# Altium schematic sheet RFID_PCB_Antenna_Main_circuit.SchDoc
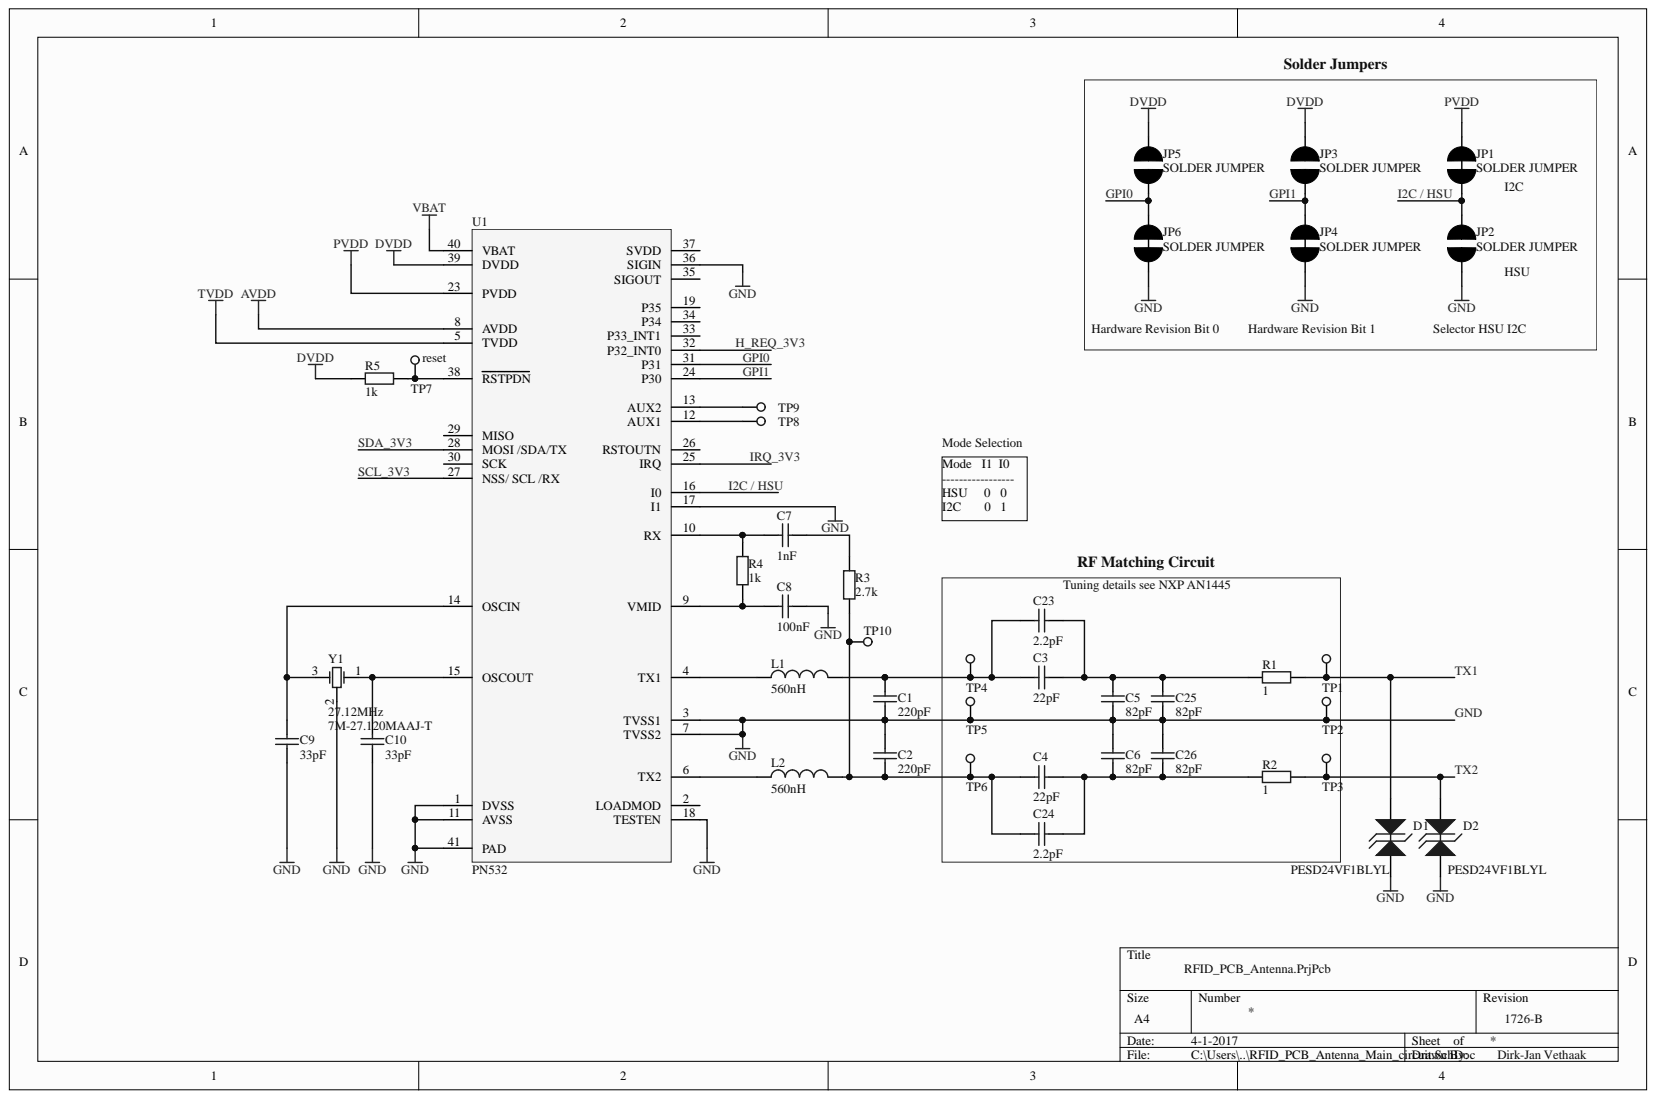
[diagram p0: RFID_PCB_Antenna_Main_circuit.SchDoc]

## Parts
C1  220pF  [cap0402]
C2  220pF  [cap0402]
C3  22pF  [cap0402]
C4  22pF  [cap0402]
C5  82pF  [cap0402]
C6  82pF  [cap0402]
C7  1nF  [cap0402]
C8  100nF  [cap0402]
C9  33pF  [cap0402]
C10  33pF  [cap0402]
C23  2.2pF  [cap0402]
C24  2.2pF  [cap0402]
C25  82pF  [cap0402]
C26  82pF  [cap0402]
D1  PESD24VF1BL  Manufacturer Part Number: PESD24VF1BLYL  [DFN65P100X50-2N]
D2  PESD24VF1BL  Manufacturer Part Number: PESD24VF1BLYL  [DFN65P100X50-2N]
JP1  SOLDER JUMPER  [Jumper Solder 0402]
JP2  SOLDER JUMPER  [Jumper Solder 0402]
JP3  SOLDER JUMPER  [Jumper Solder 0402]
JP4  SOLDER JUMPER  [Jumper Solder 0402]
JP5  SOLDER JUMPER  [Jumper Solder 0402]
JP6  SOLDER JUMPER  [Jumper Solder 0402]
L1  560nH  [C0603]
L2  560nH  [C0603]
R1  1  [RESC1105X04N]
R2  1  [RESC1105X04N]
R3  2.7k  [RESC1105X04N]
R4  1k  [RESC1105X04N]
R5  1k  [RESC1105X04N]
TP1  Testpoint  [Testpoint]  (value not drawn)
TP2  Testpoint  [Testpoint]  (value not drawn)
TP3  Testpoint  [Testpoint]  (value not drawn)
TP4  Testpoint  [Testpoint]  (value not drawn)
TP5  Testpoint  [Testpoint]  (value not drawn)
TP6  Testpoint  [Testpoint]  (value not drawn)
TP7  Testpoint  [TESTPOINT]  (value not drawn)
TP8  Testpoint  [Testpoint]  (value not drawn)
TP9  Testpoint  [Testpoint]  (value not drawn)
TP10  Testpoint  [Testpoint]  (value not drawn)
U1  PN532  [QFN50P600X600X100_HS-41N]
Y1  27.12MHz  [DFN170P250X71-4N]

## Nets
AVDD: U1.8(AVDD)
DVDD: JP3.2 JP5.2 R5.2 U1.39(DVDD)
PVDD: JP1.2 U1.23(PVDD)
TVDD: U1.5(TVDD)
VBAT: U1.40(VBAT)
GND: C1.1 C2.2 C5.1 C6.2 C8.1 C9.1 C10.1 C25.1 C26.2 D1.1 D2.1 JP2.1 JP4.1 JP6.1 TP2.1 TP5.1 U1.1(DVSS) U1.3(TVSS1) U1.7(TVSS2) U1.11(AVSS) U1.17(I1) U1.18(TESTEN) U1.36(SIGIN) U1.41(PAD) Y1.2
GPI0: JP5.1 JP6.2 U1.31(P31)
GPI1: JP3.1 JP4.2 U1.24(P30)
H_REQ_3V3: U1.32(P32_INT0)
I2C / HSU: JP1.1 JP2.2 U1.16(I0)
IRQ_3V3: U1.25(IRQ)
SCL_3V3: U1.27(NSS/_SCL_/RX)
SDA_3V3: U1.28(MOSI_/SDA/TX)
TX1: D1.2 R1.2 TP1.1
TX2: D2.2 R2.2 TP3.1
NetC1_2: C1.2 C3.1 C23.1 L1.2 TP4.1
NetC2_1: C2.1 C4.1 C24.1 L2.2 R3.1 TP6.1 TP10.1
NetC3_2: C3.2 C5.2 C23.2 C25.2 R1.1
NetC4_2: C4.2 C6.1 C24.2 C26.1 R2.1
NetC7_1: C7.1 R4.2 U1.10(RX)
NetC7_2: C7.2 R3.2
NetC8_2: C8.2 R4.1 U1.9(VMID)
NetC9_2: C9.2 U1.14(OSCIN) Y1.3
NetC10_2: C10.2 U1.15(OSCOUT) Y1.1
NetL1_1: L1.1 U1.4(TX1)
NetL2_1: L2.1 U1.6(TX2)
NetR5_1: R5.1 TP7.1 U1.38(RSTPDN)
NetTP8_1: TP8.1 U1.12(AUX1)
NetTP9_1: TP9.1 U1.13(AUX2)

Not connected: U1 2(LOADMOD) 19(P35) 26(RSTOUTN) 29(MISO) 30(SCK) 33(P33_INT1) 34(P34) 35(SIGOUT) 37(SVDD)

## Library data of the parts
C1 C2 = C0402: Manufacturer: Murata Electronics North America; Manufacturer Part Number: GRM1555C1H221JA01D; Temperature Coefficient: C0G, NP0; Tolerance: ±5%; Voltage - Rated: 50V; Applications: General Purpose; Capacitance: 220pF; Category: Capacitors; Component Kind: Standard; ComponentLink1Description: http://www.murata.com/~/media/webrenewal/support/library/catalog/products/capacitor/mlcc/c02e.pdf; ComponentLink1URL: http://www.murata.com/~/media/webrenewal/support/library/catalog/products/capacitor/mlcc/c02e.pdf; Description: C0402; Features: -; Height - Seated (Max): -; Lead Spacing: -; Lead Style: -; Mounting Type: Surface Mount, MLCC; Operating Temperature: -55°C ~ 125°C; Package / Case: 0402 (1005 Metric); Packaging: Tape & Reel (TR); Pricing 1: 10000=0.00442, 20000=0.00421, 30000=0.004, 50000=0.00358, 100000=0.00289 (EUR); Ratings: -; RoHS: RoHS Compliant; Size / Dimension: 0.039" L x 0.020" W (1.00mm x 0.50mm); Stock 1: 650000; Supplier 1: Digi-Key; Supplier Part Number 1: 490-1293-2-ND; Thickness (Max): 0.022" (0.55mm); Library Name: RFIDboard.SchLib
C3 C4 = C0402: Manufacturer: Murata Electronics North America; Manufacturer Part Number: GRM1555C1H220FA01D; Temperature Coefficient: C0G, NP0; Tolerance: ±1%; Voltage - Rated: 50V; Applications: General Purpose; Capacitance: 22pF; Category: Capacitors; Component Kind: Standard; ComponentLink1Description: http://www.murata.com/~/media/webrenewal/support/library/catalog/products/capacitor/mlcc/c02e.pdf; ComponentLink1URL: http://www.murata.com/~/media/webrenewal/support/library/catalog/products/capacitor/mlcc/c02e.pdf; Description: C0402; Features: -; Height - Seated (Max): -; Lead Spacing: -; Lead Style: -; Mounting Type: Surface Mount, MLCC; Operating Temperature: -55°C ~ 125°C; Package / Case: 0402 (1005 Metric); Packaging: Tape & Reel (TR); Pricing 1: 10000=0.00677, 20000=0.00645, 30000=0.00613, 50000=0.00548, 100000=0.00443 (EUR); Ratings: -; RoHS: RoHS Compliant; Size / Dimension: 0.039" L x 0.020" W (1.00mm x 0.50mm); Stock 1: 150000; Supplier 1: Digi-Key; Supplier Part Number 1: 490-8589-2-ND; Thickness (Max): 0.022" (0.55mm); Library Name: RFIDboard.SchLib
C5 C6 C25 C26 = C0402: Manufacturer: AVX; Manufacturer Part Number: 04025A820FAT2A; Capacitance: 82; Capacitance Tolerance: ± 1%; Capacitor Terminals: SMD; Ceramic Capacitor Case: 0402 [1005 Metric]; Dielectric Characteristic: C0G / NP0; External Depth: 0.5; External Length / Height: 0.25; External Width: 1; No. of Pins: 2; SVHC: No SVHC (17-Dec-2015); Temperature Coefficient: 0ppm/°C ± 30ppm/°C<!--FANDB--><div class="features-and-benefits-container"><div class="features-and-benefits-description"><span class="features-benefits-value">The AVX multilayer ceramic capacitors with EIA class I, C0G (NP0) dielectric material are highly stable ceramic chip capacitors for general purpose applications. This multilayer ceramic capacitor is a monolithic block of ceramic containing two sets of offset, interleaved planar electrodes that extend to two opposite surfaces of ceramic dielectric.</span></div><div class="features-and-benefits-list"><ul class="features-and-benefits-list-list"><li class="features-and-benefits-list-item">Capacitance value ranges from 0.5pF to 0.1?F</li><li class="features-and-benefits-list-item">EIA 0101, 0201, 0402, 0603, 0805, 1206, 1210, 1812, 1825, 2220, 2225 case sizes available</li><li class="features-and-benefits-list-item">Rated DC voltage of 6.3V, 10V, 16V, 25V, 50V, 100V, 200V, 500V</li><li class="features-and-benefits-list-item">±0.10pF, ±0.25pF, ±0.50pF, ±1%, ±2%, ±5% and ±10% tolerance</li><li class="features-and-benefits-list-item">Nickel (Ni) and tin (Sn) plated terminations</li><li class="features-and-benefits-list-item">Load life of 1000h at 125?C</li><li class="features-and-benefits-list-item">Operating temperature range -55?C to 125; Voltage Rating: 50; Capacitance Tolerance ±: ± 1%; Component Kind: Standard; ComponentLink1Description: http://www.farnell.com/datasheets/425357.pdf; ComponentLink1URL: http://www.farnell.com/datasheets/425357.pdf; Description: C0402; MSL: MSL 1 - Unlimited; Operating Temperature Max: 125; Operating Temperature Min: -55; Operating Temperature Range: -55°C to +125; Packaging: Cut Tape; Pricing 1: 10=0.379, 100=0.076, 500=0.038, 2500=0.0228, 5000=0.019, 10000=0.0163, 50000=0.0143, 100000=0.0127 (GBP); Product Range: -; RoHS: YES; Stock 1: 22436; Supplier 1: Farnell; Supplier Part Number 1: 1740575; Library Name: RFIDboard.SchLib
C7 = C0402: Manufacturer Part Number: VJ0402Y102KXAAC; Brand: Vishay / Vitramon; Capacitance: 1000 pF; Dielectric: X7R; Qualification: Commercial; Tolerance: 10 %; Type: Commercial SMD MLCCs; Voltage Rating DC: 50 VDC; Case Code - in: 0402; Case Code - mm: 1005; Category: Multilayer Ceramic Capacitors MLCC - SMD/SMT; Component Kind: Standard; ComponentLink1Description: http://www.mouser.com/ds/2/427/vjcommercialseries-222796.pdf; ComponentLink1URL: http://www.mouser.com/ds/2/427/vjcommercialseries-222796.pdf; Description: C0402; Height: 0.6 mm; Length: 1 mm; Manufacturer: Vishay / Vitramon; Maximum Operating Temperature: + 125 C; Minimum Operating Temperature: - 55 C; Minimum Order Quantity: 1; Package / Case: 0402 (1005 metric); Packaging: Reel; Pricing 1: 1=0.060, 50=0.050, 100=0.044, 500=0.043, 5000=0.043 (USD); Product: General Type MLCCs; Product Category: Ceramic Chip Capacitors; Reeling: True; RoHS: RoHS Compliant; RoHS - Mouser: Y; Series: VJ COMM; Stock 1: 19151; Supplier 1: Mouser; Supplier Part Number 1: 77-VJ0402Y102KXAAC; Termination Style: SMD/SMT; Voltage Rating AC: -; Width: 0.5 mm; Library Name: RFIDboard.SchLib
C8 = C0402: Manufacturer Part Number: VJ0402G104KXQCW1BC; Brand: Vishay / Vitramon; Capacitance: 0.1 uF; Dielectric: X5R; Qualification: Commercial; Tolerance: 10 %; Type: Ultra Small Commodity MLCCs; Voltage Rating DC: 10 VDC; Case Code - in: 0402; Case Code - mm: 1005; Category: Multilayer Ceramic Capacitors MLCC - SMD/SMT; Component Kind: Standard; ComponentLink1Description: http://www.mouser.com/ds/2/427/vjw1bcbascomseries-223529.pdf; ComponentLink1URL: http://www.mouser.com/ds/2/427/vjw1bcbascomseries-223529.pdf; Description: C0402; Height: 0.55 mm; Length: 1 mm; Manufacturer: Vishay / Vitramon; Maximum Operating Temperature: + 85 C; Minimum Operating Temperature: - 55 C; Minimum Order Quantity: 1; Package / Case: 0402 (1005 metric); Packaging: Reel; Pricing 1: 1=0.100, 50=0.080, 100=0.066, 500=0.047, 1000=0.028, 10000=0.021, 20000=0.019, 50000=0.012, 100000=0.010 (USD); Product: General Type MLCCs; Product Category: Ceramic Chip Capacitors; Reeling: True; RoHS: RoHS Compliant; RoHS - Mouser: Y; Series: VJ W1BC; Stock 1: 26687; Supplier 1: Mouser; Supplier Part Number 1: 77-VJ0402G104KXQCBC; Termination Style: SMD/SMT; Voltage Rating AC: -; Width: 0.5 mm; Library Name: RFIDboard.SchLib
C9 C10 = C0402: Manufacturer: MURATA; Manufacturer Part Number: GRM1555C1H330JA01D; Capacitance: 33; Capacitance Tolerance: ± 5%; Ceramic Capacitor Case: 0402 [1005 Metric]; Dielectric Characteristic: C0G / NP0; Product Range: GRM Series; SVHC: No SVHC (17-Dec-2015; Voltage Rating: 50; Component Kind: Standard; ComponentLink1Description: http://www.farnell.com/datasheets/2047983.pdf; ComponentLink1URL: http://www.farnell.com/datasheets/2047983.pdf; Description: C0402; MSL: MSL 1 - Unlimited; Operating Temperature Max: 125; Operating Temperature Min: -55; Packaging: Cut Tape; Pricing 1: 10=0.0078, 100=0.0064, 500=0.005, 2500=0.0033, 5000=0.0032, 10000=0.0026, 50000=0.002, 100000=0.0019 (GBP); RoHS: YES; Stock 1: 32150; Supplier 1: Farnell; Supplier Part Number 1: 2434643; Library Name: RFIDboard.SchLib
C23 C24 = C0402: Manufacturer: MURATA; Manufacturer Part Number: GRM1555C1H2R2CA01D; Capacitance: 2.2; Capacitance Tolerance: ± 0.25; Ceramic Capacitor Case: 0402 [1005 Metric]; Dielectric Characteristic: C0G / NP0; Product Range: GRM Series; SVHC: No SVHC (17-Dec-2015)<!--FANDB--><div class="features-and-benefits-container"><div class="features-and-benefits-description"><span class="features-benefits-value">The GRM1555C1H2R2CA01D is a Multilayer Ceramic Capacitor for general purpose, C0G/NP0 dielectric and 0402 case. Since the external electrodes consist of a plated structure, the product is excellent in soldering heat resistance. The profile dimensions have been standardized with high precision, therefore high reliability can be acquired in the case of automatic mounting.</span></div><div class="features-and-benefits-list"><ul class="features-and-benefits-list-list"><li class="features-and-benefits-list-item">High reliability with no polarity</li><li class="features-and-benefits-list-item">Low impedance in high frequencies and excellent in pulse response and noise elimination</li></ul></div><div class="features-and-benefits-applications"><ul class="features-and-benefits-value"><li class="features-and-benefits-list-item">Industrial</li></ul></div></div>; Voltage Rating: 50; Component Kind: Standard; ComponentLink1Description: http://www.farnell.com/datasheets/2047874.pdf; ComponentLink1URL: http://www.farnell.com/datasheets/2047874.pdf; Description: C0402; MSL: MSL 1 - Unlimited; Operating Temperature Max: 125; Operating Temperature Min: -55; Packaging: Cut Tape; Pricing 1: 10=0.0091, 100=0.0057, 500=0.005, 2500=0.0033, 5000=0.0032, 10000=0.0024, 50000=0.002, 100000=0.0018 (GBP); RoHS: YES; Stock 1: 133410; Supplier 1: Farnell; Supplier Part Number 1: 2434609; Library Name: RFIDboard.SchLib
D1 D2 = Biploar TVS Diode: TVS DIODE 24VWM 17VC DFN1006-2; Manufacturer: NXP Semiconductors; Bidirectional Channels: 1; Capacitance @ Frequency: 0.3pF @ 1MHz; Current - Peak Pulse (10/1000µs): 1A (8/20µs); Power Line Protection: No; Type: Zener; Voltage - Breakdown (Min): 24.5V; Voltage - Clamping (Max) @ Ipp: 17V; Voltage - Reverse Standoff (Typ): 24V (Max); Applications: Automotive; Category: Circuit Protection; Component Kind: Standard; ComponentLink1Description: http://www.nxp.com/documents/data_sheet/PESD24VF1BL.pdf; ComponentLink1URL: http://www.nxp.com/documents/data_sheet/PESD24VF1BL.pdf; Mounting Type: Surface Mount; Operating Temperature: -55°C ~ 150°C (TA); Package / Case: SOD-882; Packaging: Tape & Reel (TR); Power - Peak Pulse: -; Pricing 1: 10000=0.09736, 30000=0.0893, 50000=0.08595, 100000=0.08393, 250000=0.08259 (EUR); RoHS: RoHS Compliant; Stock 1: 30000; Supplier 1: Digi-Key; Supplier Device Package: SOD-882; Supplier Part Number 1: 568-12543-2-ND; Unidirectional Channels: -; Library Name: RFIDboard.SchLib
JP1-JP6 = (no symbol name): Manufacturer: VISHAY; Manufacturer Part Number: CRCW04020000Z0ED; ID: Library_CON-0010; Power Rating: 63; Product Range: AEC-Q200 CRCW Series; Resistance: 0; Resistor Case Style: 0402 [1005 Metric]; Resistor Element Material: Thick Film; Resistor Mounting: Surface Mount Device<!--FANDB--><div class="features-and-benefits-container"><div class="features-and-benefits-description"><span class="features-benefits-value">The Vishay D/CRCW e3 series are standard thick film chip resistors. The resistance range includes E96 and E24 decade values from 1ohm to 10Mohm. CRCW e3 series resistors have a pure tin solder contacts on the Ni barrier layer that provides compatibility with reflow soldering process.</span></div><div class="features-and-benefits-list"><ul class="features-and-benefits-list-list"><li class="features-and-benefits-list-item">Automotive grade AEC-Q200 qualified</li><li class="features-and-benefits-list-item">EIA 0402, 0603, 0805, 1206, 1210, 1218, 2010, 2512 standard case sizes available</li><li class="features-and-benefits-list-item">Stability &Delta; Temperature Coefficient: ± 100; Voltage Rating: 50; Component Kind: Standard; ComponentLink1Description: http://www.farnell.com/datasheets/2045673.pdf; ComponentLink1URL: http://www.farnell.com/datasheets/2045673.pdf; ComponentLink2Description: http://www.farnell.com/cad/1551206.zip; ComponentLink2URL: http://www.farnell.com/cad/1551206.zip; Description: SOLDER JUMPER; Mfg: -; Mfg code: -; MSL: MSL 1 - Unlimited; Packaging: Cut Tape; Pricing 1: 10=0.0194, 300=0.0152, 1000=0.0099, 2500=0.0078, 5000=0.0052 (GBP); Resistance Tolerance: -; RoHS: Y-EX; Stock 1: 111126; Supplier 1: Farnell; Value: SOLDER JUMPER; Library Name: RFIDboard.SchLib
L1 L2 = (no symbol name): Murata LQW18CNR56J00D 560nH 5%; Manufacturer: Murata Electronics North America; Current Rating: 450mA; DC Resistance (DCR): 480 mOhm Max; Frequency - Self Resonant: 540MHz; Frequency - Test: 10MHz; Material - Core: Ferrite; Shielding: Unshielded; Type: Wirewound; Category: Inductors, Coils, Chokes; Component Kind: Standard; ComponentLink1Description: http://www.murata.com/~/media/webrenewal/support/library/catalog/products/inductor/chip/o05e.ashx; ComponentLink1URL: http://www.murata.com/~/media/webrenewal/support/library/catalog/products/inductor/chip/o05e.ashx; Current - Saturation: -; Height - Seated (Max): 0.037" (0.95mm); Inductance: 560nH; Manufacturer Part Number: LQW18CNR56J00D; Mounting Type: Surface Mount; Operating Temperature: -40°C ~ 85°C; Package / Case: 0603 (1608 Metric); Packaging: Cut Tape (CT); Pricing 1: 1=0.47, 10=0.415, 25=0.39, 50=0.3376, 100=0.3117, 250=0.29612, 500=0.27014, 750=0.23897, 1000=0.22858 (EUR); Q @ Freq: -; Ratings: -; RoHS: RoHS Compliant; Size / Dimension: 0.063" L x 0.031" W (1.60mm x 0.80mm); Stock 1: 10277; Supplier 1: Digi-Key; Supplier Part Number 1: 490-10774-1-ND; Tolerance: ±5%; Library Name: RFIDboard.SchLib
R1 R2 = R0402: Manufacturer: MULTICOMP; Manufacturer Part Number: MCMR04W1R00FTL; Power Rating: 62.5; Product Range: MCMR Series; Resistance Tolerance: ± 1%; Resistor Case Style: 0402 [1005 Metric]; Resistor Element Material: Ceramic; Resistor Mounting: Surface Mount Device<!--FANDB--><div class="features-and-benefits-container"><div class="features-and-benefits-description"><span class="features-benefits-value">The Multicomp MCMR series resistors are automotive grade, anti sulphured thick film chip resistor. The resistance range includes E24 and E96 decade values from 1ohm to 10Mohm. These resistors are constructed on top of a high grade ceramic body.</span></div><div class="features-and-benefits-list"><ul class="features-and-benefits-list-list"><li class="features-and-benefits-list-item">EIA 0402, 0603, 0805, 1206 chip sizes available</li><li class="features-and-benefits-list-item">High reliability and stability</li><li class="features-and-benefits-list-item">Automotive grade AEC-Q200 compliant</li><li class="features-and-benefits-list-item">Complaint with (IEC 60068-1) 55/155/56 climatic category</li><li class="features-and-benefits-list-item">Maximum operation voltage of 50V, 75V, 150V and 200V</li><li class="features-and-benefits-list-item">Power rating of 62.5mW, 100mW, 125mW and 250mW</li><li class="features-and-benefits-list-item">Available in ±1% and ±5% tolerance</li><li class="features-and-benefits-list-item">Temperature coefficient (TCR) of +200ppm/°C, +100ppm/°C and -200ppm/°C to +400ppm/°C</li><li class="features-and-benefits-list-item">Operating temperature range -55°C to 155; SVHC: No SVHC (17-Dec-2015); Temperature Coefficient: -200ppm/°C to +400; Voltage Rating: 50; Component Kind: Standard; ComponentLink1Description: http://www.farnell.com/datasheets/1716705.pdf; ComponentLink1URL: http://www.farnell.com/datasheets/1716705.pdf; Description: R0402; MSL: -; Packaging: Cut Tape; Pricing 1: 10=0.0192, 100=0.0151, 500=0.0109, 2500=0.0047, 5000=0.004, 10000=0.0033, 50000=0.0023, 100000=0.002 (GBP); Resistance: 1; RoHS: YES; Stock 1: 17702; Supplier 1: Farnell; Supplier Part Number 1: 2072500; Library Name: RFIDboard.SchLib
R3 = R0402: Manufacturer: Vishay Dale; Manufacturer Part Number: CRCW04022K70FKED; Power (Watts): 0.063W, 1/16W; Temperature Coefficient: ±100ppm/°C; Tolerance: ±1%; Category: Resistors; Component Kind: Standard; ComponentLink1Description: http://www.vishay.com/docs/20035/dcrcwe3.pdf; ComponentLink1URL: http://www.vishay.com/docs/20035/dcrcwe3.pdf; Composition: Thick Film; Description: R0402; Features: Automotive AEC-Q200; Height: 0.016" (0.40mm); Number of Terminations: 2; Operating Temperature: -55°C ~ 155°C; Package / Case: 0402 (1005 Metric); Packaging: Tape & Reel (TR); Pricing 1: 10000=0.00292, 30000=0.00256, 50000=0.00235, 100000=0.0023 (EUR); Resistance (Ohms): 2.7k; RoHS: RoHS Compliant; Size / Dimension: 0.039" L x 0.020" W (1.00mm x 0.50mm); Stock 1: 70000; Supplier 1: Digi-Key; Supplier Device Package: 0402 (1005 Metric); Supplier Part Number 1: 541-2.70KLTR-ND; Library Name: RFIDboard.SchLib
R4 R5 = R0402: Manufacturer: Vishay Beyschlag; Manufacturer Part Number: MCS04020C1001FE000; Power (Watts): 0.1W, 1/10W; Temperature Coefficient: ±50ppm/°C; Tolerance: ±1%; Category: Resistors; Component Kind: Standard; ComponentLink1Description: http://www.vishay.com/docs/28705/mcx0x0xpro.pdf; ComponentLink1URL: http://www.vishay.com/docs/28705/mcx0x0xpro.pdf; Composition: Thin Film; Description: R0402; Features: -; Height: 0.015" (0.37mm); Number of Terminations: 2; Operating Temperature: -55°C ~ 155°C; Package / Case: 0402 (1005 Metric); Packaging: Tape & Reel (TR); Pricing 1: 10000=0.02474, 30000=0.0235, 50000=0.02302, 100000=0.02253 (EUR); Resistance (Ohms): 1k; RoHS: RoHS Compliant; Size / Dimension: 0.039" L x 0.020" W (1.00mm x 0.50mm); Stock 1: 80000; Supplier 1: Digi-Key; Supplier Device Package: 0402; Supplier Part Number 1: MCS0402-1.00K-CFTR-ND; Library Name: RFIDboard.SchLib
TP1-TP6 TP8-TP10 = Testpoint: ID: Library_MISC-0001; Component Kind: Standard; Description: Testpoint; Faber ItemCode: -; Info: Testpoint; Mfg: -; Mfg code: -; Value: Testpoint; Library Name: RFIDboard.SchLib
TP7 = Testpoint 1mm: ID: Library_MISC-0001; Component Kind: Standard; Description: Testpoint; Faber ItemCode: -; Info: Testpoint; Mfg: -; Mfg code: -; Value: Testpoint; Library Name: RFIDboard.SchLib
U1 = PN532: PN5321A3HN; Manufacturer: NXP; Manufacturer Part Number: PN5321A3HN/C106;55; Component Kind: Standard; ComponentLink1Description: http://www.farnell.com/datasheets/1751691.pdf; ComponentLink1URL: http://www.farnell.com/datasheets/1751691.pdf; ComponentLink2Description: http://www.farnell.com/cad/1722221.zip; ComponentLink2URL: http://www.farnell.com/cad/1722221.zip; Pricing 1: 1=8.6, 10=7.23, 100=5.37, 300=5.17, 700=5.08, 2000=4.34, 3000=4.27 (GBP); RoHS: YES; Stock 1: 1123; Supplier 1: Farnell; Supplier Part Number 1: 1902845; Library Name: RFIDboard.SchLib
Y1 = XTAL-3: 7M-27.120MAAJ-T; Manufacturer: TXC CORPORATION; ESR (Equivalent Series Resistance): 60 Ohm; Frequency Stability: ±30ppm; Load Capacitance: 18pF; Operating Mode: Fundamental; Category: Crystals and Oscillators; Component Kind: Standard; ComponentLink1Description: http://www.txccrystal.com/images/pdf/7m.pdf; ComponentLink1URL: http://www.txccrystal.com/images/pdf/7m.pdf; Frequency: 27.12MHz; Frequency Tolerance: ±30ppm; Height: 0.031" (0.80mm); Manufacturer Part Number: 7M-27.120MAAJ-T; Mounting Type: Surface Mount; Operating Temperature: -20°C ~ 70°C; Package / Case: 4-SMD, No Lead (DFN, LCC); Packaging: Cut Tape (CT); Pricing 1: 1=0.85, 10=0.748, 50=0.6988, 100=0.6185, 500=0.58444, 1000=0.48858 (EUR); Ratings: -; RoHS: RoHS Compliant; Size / Dimension: 0.126" L x 0.098" W (3.20mm x 2.50mm); Stock 1: 37105; Supplier 1: Digi-Key; Supplier Part Number 1: 887-1424-1-ND; Type: MHz Crystal; Library Name: RFIDboard.SchLib
